# Altium schematic sheet IMX6UL_ALPHA_PIN.SchDoc(IMX6UL_ALPHA_PIN)
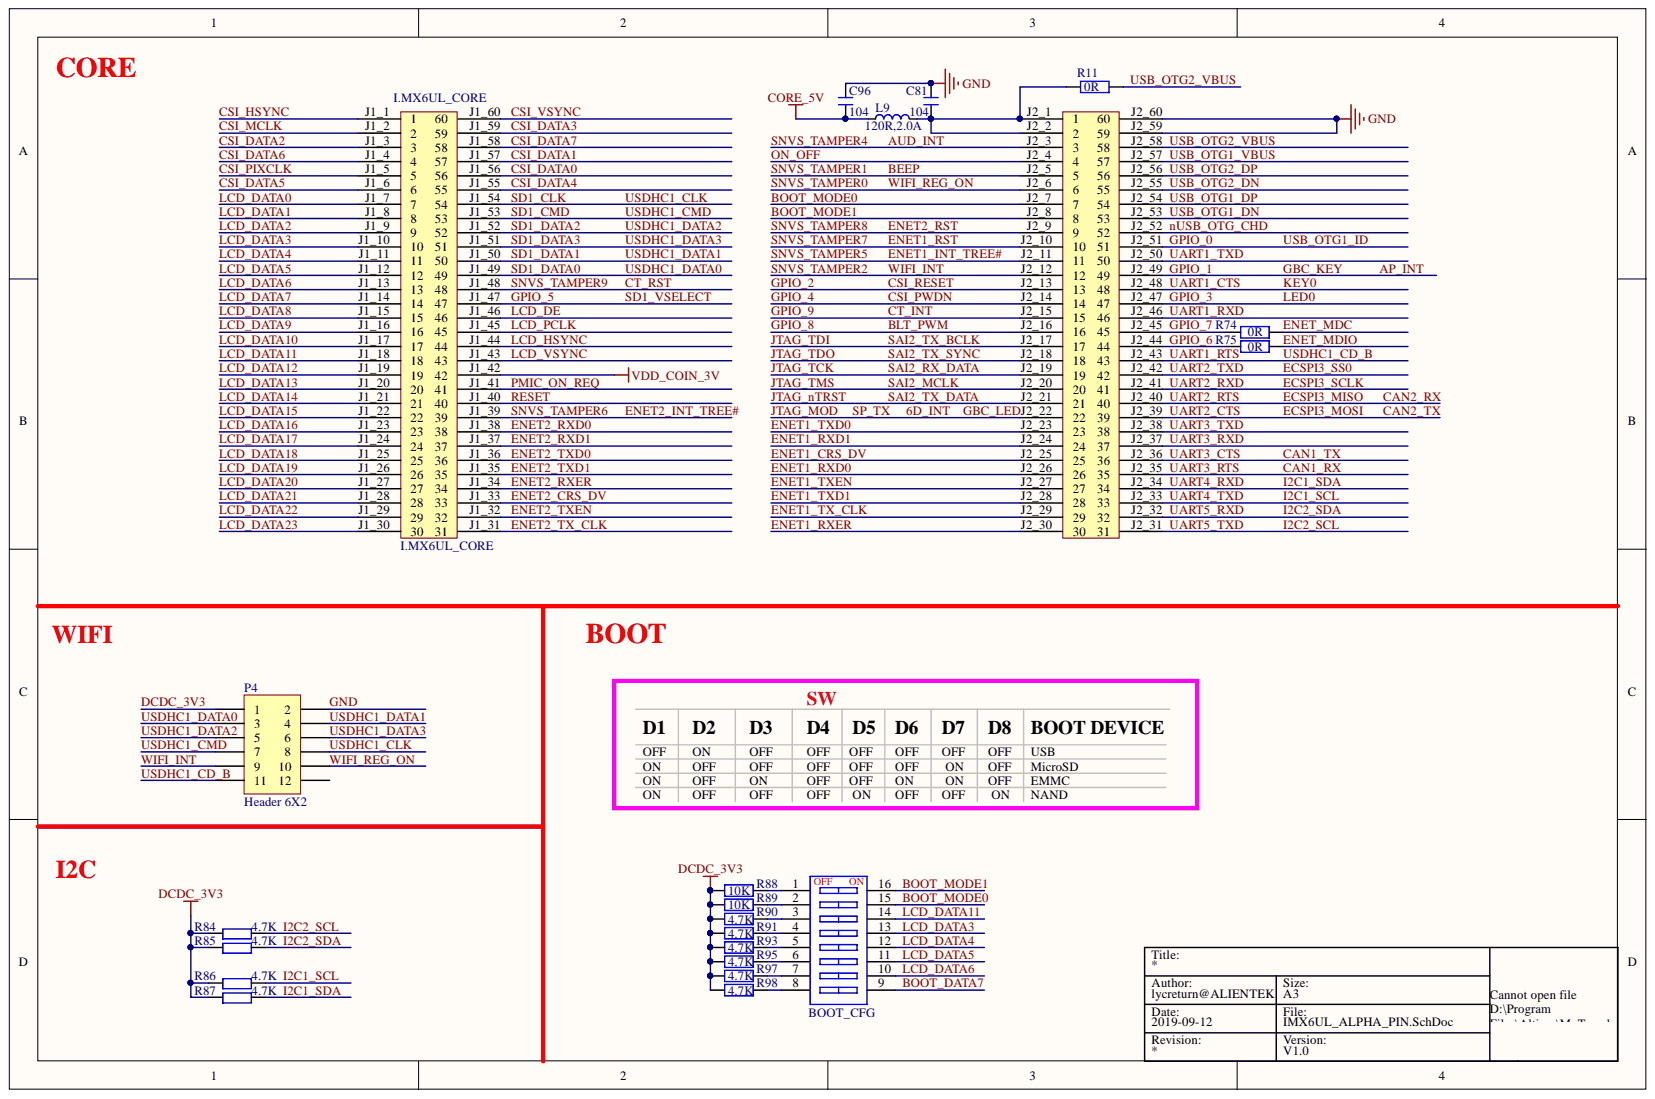
[diagram p0: IMX6UL_ALPHA_PIN.SchDoc]

## Parts
BOOT_CFG  CSW-8P  [CSW DIP-8P]  (value not drawn)
C81  104  [C 0603]
C96  104  [C 0603]
I.MX6UL_CORE  I.MX6UL_CORE  [I.MX6UL_CORE]
L9  120R,2.0A  [L 0603]
P4  Header 6X2  [HDR2X6]
R11  0R  [R 0603]
R74  0R  [R 0603]
R75  0R  [R 0603]
R84  4.7K  [R 0603]
R85  4.7K  [R 0603]
R86  4.7K  [R 0603]
R87  4.7K  [R 0603]
R88  10K  [R 0603]
R89  10K  [R 0603]
R90  4.7K  [R 0603]
R91  4.7K  [R 0603]
R93  4.7K  [R 0603]
R95  4.7K  [R 0603]
R97  4.7K  [R 0603]
R98  4.7K  [R 0603]

## Nets
CORE_5V: C96.1 L9.1
VDD_COIN_3V: I.MX6UL_CORE.J1_42
6D_INT: I.MX6UL_CORE.J2_22(GBC_LED)
AP_INT: I.MX6UL_CORE.J2_49
AUD_INT: I.MX6UL_CORE.J2_3
BEEP: I.MX6UL_CORE.J2_5
BLT_PWM: I.MX6UL_CORE.J2_16
BOOT_DATA7: BOOT_CFG.9
BOOT_MODE0: BOOT_CFG.15 I.MX6UL_CORE.J2_7
BOOT_MODE1: BOOT_CFG.16 I.MX6UL_CORE.J2_8
CAN1_RX: I.MX6UL_CORE.J2_35
CAN1_TX: I.MX6UL_CORE.J2_36
CAN2_RX: I.MX6UL_CORE.J2_40
CAN2_TX: I.MX6UL_CORE.J2_39
CSI_DATA0: I.MX6UL_CORE.J1_56(CSI_DATA0)
CSI_DATA1: I.MX6UL_CORE.J1_57
CSI_DATA2: I.MX6UL_CORE.J1_3
CSI_DATA3: I.MX6UL_CORE.J1_59
CSI_DATA4: I.MX6UL_CORE.J1_55(CSI_DATA4)
CSI_DATA5: I.MX6UL_CORE.J1_6
CSI_DATA6: I.MX6UL_CORE.J1_4
CSI_DATA7: I.MX6UL_CORE.J1_58(CSI_DATA7)
CSI_HSYNC: I.MX6UL_CORE.J1_1
CSI_MCLK: I.MX6UL_CORE.J1_2
CSI_PIXCLK: I.MX6UL_CORE.J1_5
CSI_PWDN: I.MX6UL_CORE.J2_14
CSI_RESET: I.MX6UL_CORE.J2_13
CSI_VSYNC: I.MX6UL_CORE.J1_60(CSI_VSYNC)
CT_INT: I.MX6UL_CORE.J2_15
CT_RST: I.MX6UL_CORE.J1_48
DCDC_3V3: P4.1 R84.1 R85.1 R86.1 R87.1 R88.1 R89.1 R90.1 R91.1 R93.1 R95.1 R97.1 R98.1
ECSPI3_SCLK: I.MX6UL_CORE.J2_41
ECSPI3_SS0: I.MX6UL_CORE.J2_42
ENET1_CRS_DV: I.MX6UL_CORE.J2_25
ENET1_INT_TREE#: I.MX6UL_CORE.J2_11
ENET1_RST: I.MX6UL_CORE.J2_10
ENET1_RXD0: I.MX6UL_CORE.J2_26
ENET1_RXD1: I.MX6UL_CORE.J2_24
ENET1_RXER: I.MX6UL_CORE.J2_30
ENET1_TX_CLK: I.MX6UL_CORE.J2_29
ENET1_TXD0: I.MX6UL_CORE.J2_23
ENET1_TXD1: I.MX6UL_CORE.J2_28
ENET1_TXEN: I.MX6UL_CORE.J2_27
ENET2_CRS_DV: I.MX6UL_CORE.J1_33
ENET2_INT_TREE#: I.MX6UL_CORE.J1_39(SNVS_TAMPER6)
ENET2_RST: I.MX6UL_CORE.J2_9
ENET2_RXD0: I.MX6UL_CORE.J1_38
ENET2_RXD1: I.MX6UL_CORE.J1_37
ENET2_RXER: I.MX6UL_CORE.J1_34
ENET2_TX_CLK: I.MX6UL_CORE.J1_31(ENET2_TX_CLK)
ENET2_TXD0: I.MX6UL_CORE.J1_36(ENET2_TXD0)
ENET2_TXD1: I.MX6UL_CORE.J1_35(ENET2_TXD1)
ENET2_TXEN: I.MX6UL_CORE.J1_32
ENET_MDC: R74.2
ENET_MDIO: R75.2
GND: C81.2 C96.2 I.MX6UL_CORE.J2_59 I.MX6UL_CORE.J2_60 P4.2
GPIO_0: I.MX6UL_CORE.J2_51
GPIO_3: I.MX6UL_CORE.J2_47
GPIO_5: I.MX6UL_CORE.J1_47
GPIO_6: I.MX6UL_CORE.J2_44 R75.1
GPIO_7: I.MX6UL_CORE.J2_45 R74.1
I2C1_SCL: I.MX6UL_CORE.J2_33 R86.2
I2C1_SDA: I.MX6UL_CORE.J2_34 R87.2
I2C2_SCL: I.MX6UL_CORE.J2_31 R84.2
I2C2_SDA: I.MX6UL_CORE.J2_32 R85.2
JTAG_NTRST: I.MX6UL_CORE.J2_21
JTAG_TCK: I.MX6UL_CORE.J2_19
JTAG_TDI: I.MX6UL_CORE.J2_17
JTAG_TDO: I.MX6UL_CORE.J2_18
JTAG_TMS: I.MX6UL_CORE.J2_20
KEY0: I.MX6UL_CORE.J2_48
LCD_DATA0: I.MX6UL_CORE.J1_7
LCD_DATA1: I.MX6UL_CORE.J1_8
LCD_DATA2: I.MX6UL_CORE.J1_9
LCD_DATA3: BOOT_CFG.13 I.MX6UL_CORE.J1_10
LCD_DATA4: BOOT_CFG.12 I.MX6UL_CORE.J1_11
LCD_DATA5: BOOT_CFG.11 I.MX6UL_CORE.J1_12
LCD_DATA6: BOOT_CFG.10 I.MX6UL_CORE.J1_13
LCD_DATA7: I.MX6UL_CORE.J1_14
LCD_DATA8: I.MX6UL_CORE.J1_15
LCD_DATA9: I.MX6UL_CORE.J1_16
LCD_DATA10: I.MX6UL_CORE.J1_17
LCD_DATA11: BOOT_CFG.14 I.MX6UL_CORE.J1_18
LCD_DATA12: I.MX6UL_CORE.J1_19
LCD_DATA13: I.MX6UL_CORE.J1_20
LCD_DATA14: I.MX6UL_CORE.J1_21
LCD_DATA15: I.MX6UL_CORE.J1_22
LCD_DATA16: I.MX6UL_CORE.J1_23
LCD_DATA17: I.MX6UL_CORE.J1_24
LCD_DATA18: I.MX6UL_CORE.J1_25
LCD_DATA19: I.MX6UL_CORE.J1_26
LCD_DATA20: I.MX6UL_CORE.J1_27
LCD_DATA21: I.MX6UL_CORE.J1_28
LCD_DATA22: I.MX6UL_CORE.J1_29
LCD_DATA23: I.MX6UL_CORE.J1_30
LCD_DE: I.MX6UL_CORE.J1_46
LCD_HSYNC: I.MX6UL_CORE.J1_44
LCD_PCLK: I.MX6UL_CORE.J1_45
LCD_VSYNC: I.MX6UL_CORE.J1_43
NUSB_OTG_CHD: I.MX6UL_CORE.J2_52
ON_OFF: I.MX6UL_CORE.J2_4
PMIC_ON_REQ: I.MX6UL_CORE.J1_41(PMIC_ON_REQ)
RESET: I.MX6UL_CORE.J1_40
SD1_CLK: I.MX6UL_CORE.J1_54(SD1_CLK) P4.8
SD1_CMD: I.MX6UL_CORE.J1_53(SD1_CMD) P4.7
SD1_DATA0: I.MX6UL_CORE.J1_49 P4.3
SD1_DATA1: I.MX6UL_CORE.J1_50 P4.4
SD1_DATA2: I.MX6UL_CORE.J1_52 P4.5
SD1_DATA3: I.MX6UL_CORE.J1_51 P4.6
SNVS_TAMPER0: I.MX6UL_CORE.J2_6 P4.10
SNVS_TAMPER2: I.MX6UL_CORE.J2_12 P4.9
UART1_RTS: I.MX6UL_CORE.J2_43 P4.11
UART1_RXD: I.MX6UL_CORE.J2_46
UART1_TXD: I.MX6UL_CORE.J2_50
UART3_RXD: I.MX6UL_CORE.J2_37
UART3_TXD: I.MX6UL_CORE.J2_38
USB_OTG1_DN: I.MX6UL_CORE.J2_53
USB_OTG1_DP: I.MX6UL_CORE.J2_54
USB_OTG1_VBUS: I.MX6UL_CORE.J2_57
USB_OTG2_DN: I.MX6UL_CORE.J2_55
USB_OTG2_DP: I.MX6UL_CORE.J2_56
USB_OTG2_VBUS: I.MX6UL_CORE.J2_58 R11.2
NetBOOT_CFG_1: BOOT_CFG.1 R88.2
NetBOOT_CFG_2: BOOT_CFG.2 R89.2
NetBOOT_CFG_3: BOOT_CFG.3 R90.2
NetBOOT_CFG_4: BOOT_CFG.4 R91.2
NetBOOT_CFG_5: BOOT_CFG.5 R93.2
NetBOOT_CFG_6: BOOT_CFG.6 R95.2
NetBOOT_CFG_7: BOOT_CFG.7 R97.2
NetBOOT_CFG_8: BOOT_CFG.8 R98.2
NetC81_1: C81.1 I.MX6UL_CORE.J2_1 I.MX6UL_CORE.J2_2 L9.2 R11.1

Not connected: P4 12

## Library data of the parts
BOOT_CFG = CSW-8P: 8路编码开关; 版权归属: 源创客; BOM名称: 8路编码开关; Component Kind: Standard; Library Name: 按键-开关.SchLib; 学习资源: www.ooocke.com
C81 C96 = C: 无极性贴片电容; 版权归属: 源创客; BOM名称: 无极性贴片电容; Component Kind: Standard; Library Name: 电阻-电容-电感.SchLib; 学习资源: www.ooocke.com
I.MX6UL_CORE = I.MX6UL核心板: Component Kind: Standard; Description: I.MX6UL核心板; Library Name: 模块.SchLib
L9 = L: 小功率贴片电感磁珠; 版权归属: 源创客; BOM名称: 小功率贴片电感; Component Kind: Standard; Library Name: 电阻-电容-电感.SchLib; 学习资源: www.ooocke.com
P4 = Header 6X2: Header, 6-Pin, Dual row; Component Kind: Standard; LatestRevisionDate: 17-Jul-2002; LatestRevisionNote: Re-released for DXP Platform.; Library Name: Miscellaneous Connectors.IntLib; Signal Integrity: Connector; Publisher: Altium Limited
R11 R74 R75 R88-R91 R93 R95 R97 R98 = R: 贴片电阻; 版权归属: 源创客; BOM名称: 贴片电阻; Component Kind: Standard; Library Name: 电阻-电容-电感.SchLib; 学习资源: www.ooocke.com
R84-R87 = R: Component Kind: Standard; Library Name: 电阻-电容-电感.SchLib
